# Altium schematic sheet Main_Design.SchDoc(Main_Design)
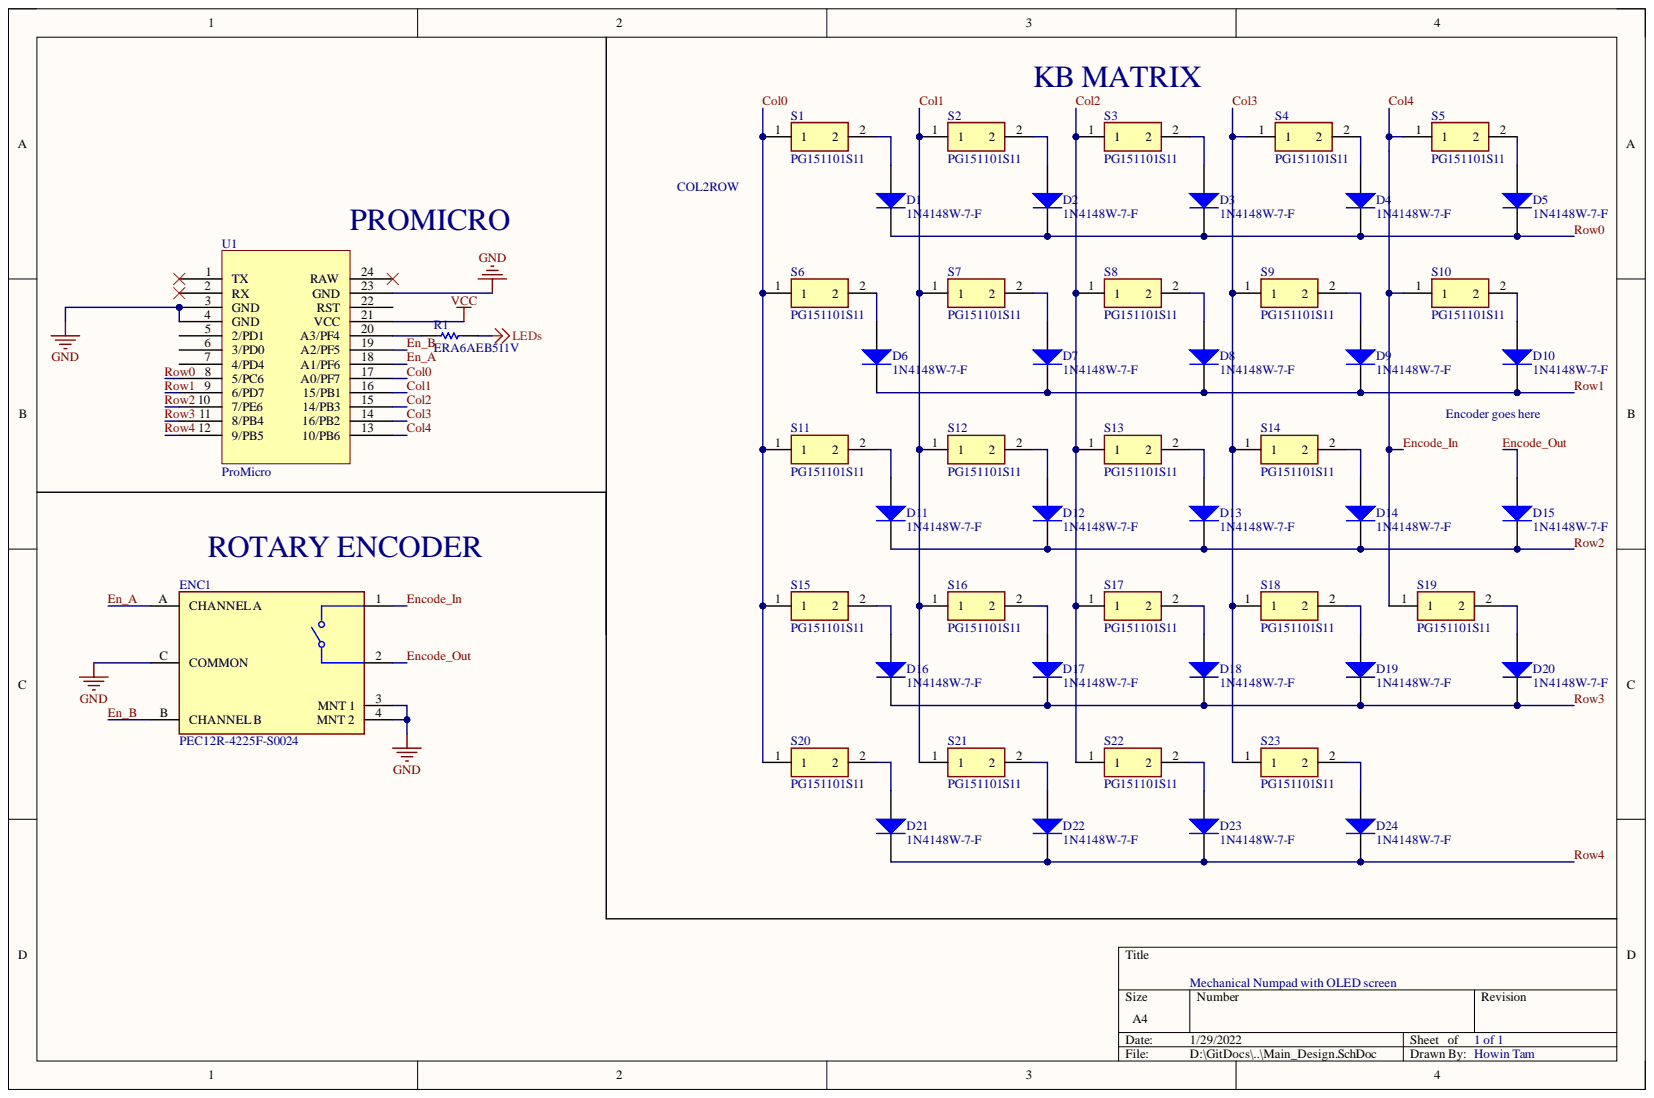
[diagram p0: Main_Design.SchDoc]

## Parts
D1  1N4148W-7-F  [DIOD-SOD123-2_V]
D2  1N4148W-7-F  [DIOD-SOD123-2_V]
D3  1N4148W-7-F  [DIOD-SOD123-2_V]
D4  1N4148W-7-F  [DIOD-SOD123-2_V]
D5  1N4148W-7-F  [DIOD-SOD123-2_V]
D6  1N4148W-7-F  [DIOD-SOD123-2_V]
D7  1N4148W-7-F  [DIOD-SOD123-2_V]
D8  1N4148W-7-F  [DIOD-SOD123-2_V]
D9  1N4148W-7-F  [DIOD-SOD123-2_V]
D10  1N4148W-7-F  [DIOD-SOD123-2_V]
D11  1N4148W-7-F  [DIOD-SOD123-2_V]
D12  1N4148W-7-F  [DIOD-SOD123-2_V]
D13  1N4148W-7-F  [DIOD-SOD123-2_V]
D14  1N4148W-7-F  [DIOD-SOD123-2_V]
D15  1N4148W-7-F  [DIOD-SOD123-2_V]
D16  1N4148W-7-F  [DIOD-SOD123-2_V]
D17  1N4148W-7-F  [DIOD-SOD123-2_V]
D18  1N4148W-7-F  [DIOD-SOD123-2_V]
D19  1N4148W-7-F  [DIOD-SOD123-2_V]
D20  1N4148W-7-F  [DIOD-SOD123-2_V]
D21  1N4148W-7-F  [DIOD-SOD123-2_V]
D22  1N4148W-7-F  [DIOD-SOD123-2_V]
D23  1N4148W-7-F  [DIOD-SOD123-2_V]
D24  1N4148W-7-F  [DIOD-SOD123-2_V]
ENC1  PEC12R-4225F-S0024  [FP-PEC12R-4225F-S0024-MFG]
R1  ERA6AEB511V  [RESC2013X60X40ML20T25]
S1  PG151101S11  [SW_PG151101S11]
S2  PG151101S11  [SW_PG151101S11]
S3  PG151101S11  [SW_PG151101S11]
S4  PG151101S11  [SW_PG151101S11]
S5  PG151101S11  [SW_PG151101S11]
S6  PG151101S11  [SW_PG151101S11]
S7  PG151101S11  [SW_PG151101S11]
S8  PG151101S11  [SW_PG151101S11]
S9  PG151101S11  [SW_PG151101S11]
S10  PG151101S11  [SW_PG151101S11]
S11  PG151101S11  [SW_PG151101S11]
S12  PG151101S11  [SW_PG151101S11]
S13  PG151101S11  [SW_PG151101S11]
S14  PG151101S11  [SW_PG151101S11]
S15  PG151101S11  [SW_PG151101S11]
S16  PG151101S11  [SW_PG151101S11]
S17  PG151101S11  [SW_PG151101S11]
S18  PG151101S11  [SW_PG151101S11]
S19  PG151101S11  [SW_PG151101S11]
S20  PG151101S11  [SW_PG151101S11]
S21  PG151101S11  [SW_PG151101S11]
S22  PG151101S11  [SW_PG151101S11]
S23  PG151101S11  [SW_PG151101S11]
U1  ProMicro  [PCBComponent_1]

## Nets
GND: ENC1.3(MNT_1) ENC1.4(MNT_2) ENC1.C(COMMON) U1.3(GND) U1.4(GND) U1.23(GND)
LEDs: R1.2
VCC: U1.21(VCC)
Col0: S1.1 S6.1 S11.1 S15.1 S20.1 U1.17(A0/PF7)
Col1: S2.1 S7.1 S12.1 S16.1 S21.1 U1.16(15/PB1)
Col2: S3.1 S8.1 S13.1 S17.1 S22.1 U1.15(14/PB3)
Col3: S4.1 S9.1 S14.1 S18.1 S23.1 U1.14(16/PB2)
Col4: ENC1.1 S5.1 S10.1 S19.1 U1.13(10/PB6)
En_A: ENC1.A(CHANNEL_A) U1.18(A1/PF6)
En_B: ENC1.B(CHANNEL_B) U1.19(A2/PF5)
Encode_Out: D15.1 ENC1.2
Row0: D1.2 D2.2 D3.2 D4.2 D5.2 U1.8(5/PC6)
Row1: D6.2 D7.2 D8.2 D9.2 D10.2 U1.9(6/PD7)
Row2: D11.2 D12.2 D13.2 D14.2 D15.2 U1.10(7/PE6)
Row3: D16.2 D17.2 D18.2 D19.2 D20.2 U1.11(8/PB4)
Row4: D21.2 D22.2 D23.2 D24.2 U1.12(9/PB5)
NetD1_1: D1.1 S1.2
NetD2_1: D2.1 S2.2
NetD3_1: D3.1 S3.2
NetD4_1: D4.1 S4.2
NetD5_1: D5.1 S5.2
NetD6_1: D6.1 S6.2
NetD7_1: D7.1 S7.2
NetD8_1: D8.1 S8.2
NetD9_1: D9.1 S9.2
NetD10_1: D10.1 S10.2
NetD11_1: D11.1 S11.2
NetD12_1: D12.1 S12.2
NetD13_1: D13.1 S13.2
NetD14_1: D14.1 S14.2
NetD16_1: D16.1 S15.2
NetD17_1: D17.1 S16.2
NetD18_1: D18.1 S17.2
NetD19_1: D19.1 S18.2
NetD20_1: D20.1 S19.2
NetD21_1: D21.1 S20.2
NetD22_1: D22.1 S21.2
NetD23_1: D23.1 S22.2
NetD24_1: D24.1 S23.2
NetR1_1: R1.1 U1.20(A3/PF4)

Not connected: U1 1(TX) 2(RX) 5(2/PD1) 6(3/PD0) 7(4/PD4) 22(RST) 24(RAW)

## Library data of the parts
D1-D24 = CMP-2000-04932-2: Fast Switching Diode, 100 V, 0.15 A, -65 to 150 degC, 2-Pin?SOD123, RoHS, Tape and Reel; Brand: DiodesIncorporated; Configuration: Single; If - Forward Current: 0.15A; Ir - Reverse Current: 1uA; Max Surge Current: 2A; Peak Reverse Voltage: 100V; Recovery Time: 4ns; Unit Weight: 0.000353oz; Vf - Forward Voltage: 1.25V; Component Kind: Standard; Datasheet URL: http://www.farnell.com/datasheets/1915529.pdf; Datasheet Version: Rev. 24-2, 10/2013; Factory Pack Quantity: 3000; Height: 1.25mm; Length: 2.85mm; Library Name: Altium Content Vault; Manufacturer: DiodesIncorporated; Manufacturer URL: http://www.diodes.com/; Maximum Operating Temperature: +150C; Minimum Operating Temperature: -65C; Mounting Style: SMD/SMT; Operating Temperature Range: -65Cto+150C; Package / Case: SOD-123; Package Reference: SOD123-2; Package URL: http://www.diodes.com/datasheets/ds18004.pdf; Packaging: Reel; Product: SwitchingDiodes; Product Category: Diodes-GeneralPurpose,Power,Switching; RoHS: True; Series: 1N4148; Type: SwitchingDiode; Width: 1.7mm
ENC1 = CMP-07421-000041-1: ROTARY ENCODER MECHANICAL 24PPR; Built in Switch: Yes; Hole Diameter: 1mm; Number of Channels: 2; Output Type: Quadrature; Pulses per Revolution: 24; Component Kind: Standard; Detent: Yes; Encoder Type: Mechanical; Height: 13.4mm; Length: 13.4mm; Library Name: Altium Content Vault; Max Operating Temperature: 70°C; Min Operating Temperature: -30°C; Mount: Through Hole; Orientation: Vertical; Package Quantity: 75; Packaging: Bulk; REACH SVHC: No SVHC; RoHS Compliant: Yes; Series: PEC12R; Termination: PC Pin; Width: 12.5mm
R1 = CMP-2001-00648-1: Manufacturer: Panasonic; Component Kind: Standard; Library Name: Altium Content Vault; Part Number: ERA6AEB511V
S1-S23 = PG151101S11: Keyswitch; MF: Kailh; Availability: Unavailable; Component Kind: Standard; Library Name: PG151101S11.IntLib; MP: PG151101S11; Package: Package; Price: None; SnapEDA_Link: https://www.snapeda.com/parts/PG151101S11/Kailh/view-part/4218555/?ref=snap
U1 = Component_1: Component Kind: Standard; Library Name: ProMicro.SchLib
